# Altium schematic sheet ESC.SchDoc
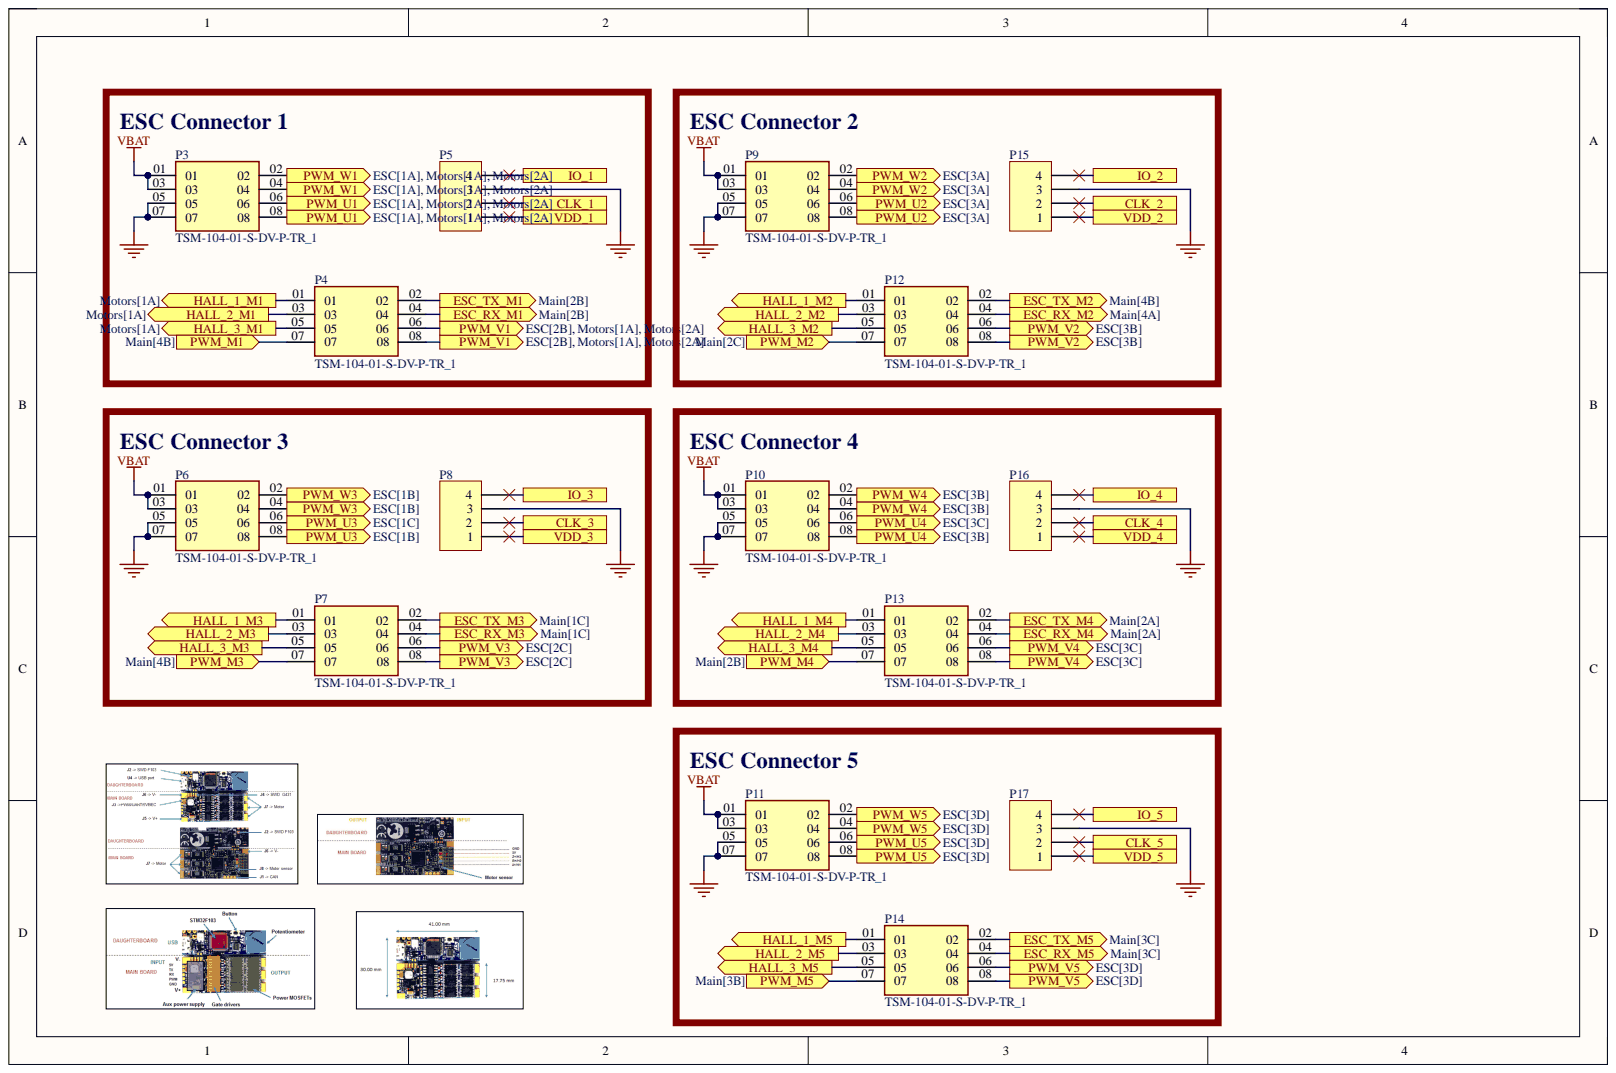
[diagram p0: ESC.SchDoc]

## Parts
P3  TSM-104-01-S-DV-P-TR_1  [TSM-104-01-S-DV-P-TR]
P4  TSM-104-01-S-DV-P-TR_1  [TSM-104-01-S-DV-P-TR]
P5  Header 3  [My_1X04]  (value not drawn)
P6  TSM-104-01-S-DV-P-TR_1  [TSM-104-01-S-DV-P-TR]
P7  TSM-104-01-S-DV-P-TR_1  [TSM-104-01-S-DV-P-TR]
P8  Header 3  [My_1X04]  (value not drawn)
P9  TSM-104-01-S-DV-P-TR_1  [TSM-104-01-S-DV-P-TR]
P10  TSM-104-01-S-DV-P-TR_1  [TSM-104-01-S-DV-P-TR]
P11  TSM-104-01-S-DV-P-TR_1  [TSM-104-01-S-DV-P-TR]
P12  TSM-104-01-S-DV-P-TR_1  [TSM-104-01-S-DV-P-TR]
P13  TSM-104-01-S-DV-P-TR_1  [TSM-104-01-S-DV-P-TR]
P14  TSM-104-01-S-DV-P-TR_1  [TSM-104-01-S-DV-P-TR]
P15  Header 3  [My_1X04]  (value not drawn)
P16  Header 3  [My_1X04]  (value not drawn)
P17  Header 3  [My_1X04]  (value not drawn)

## Ports
CLK_1, CLK_2, CLK_3, CLK_4, CLK_5, ESC_RX_M1, ESC_RX_M2, ESC_RX_M3, ESC_RX_M4, ESC_RX_M5, ESC_TX_M1, ESC_TX_M2, ESC_TX_M3, ESC_TX_M4, ESC_TX_M5, HALL_1_M1, HALL_1_M2, HALL_1_M3, HALL_1_M4, HALL_1_M5, HALL_2_M1, HALL_2_M2, HALL_2_M3, HALL_2_M4, HALL_2_M5, HALL_3_M1, HALL_3_M2, HALL_3_M3, HALL_3_M4, HALL_3_M5, IO_1, IO_2, IO_3, IO_4, IO_5, PWM_M1, PWM_M2, PWM_M3, PWM_M4, PWM_M5, PWM_U1, PWM_U2, PWM_U3, PWM_U4, PWM_U5, PWM_V1, PWM_V2, PWM_V3, PWM_V4, PWM_V5, PWM_W1, PWM_W2, PWM_W3, PWM_W4, PWM_W5, VDD_1, VDD_2, VDD_3, VDD_4, VDD_5

## Nets
GND: P3.05 P3.07 P5.3 P6.05 P6.07 P8.3 P9.05 P9.07 P10.05 P10.07 P11.05 P11.07 P15.3 P16.3 P17.3
VBAT: P3.01 P3.03 P6.01 P6.03 P9.01 P9.03 P10.01 P10.03 P11.01 P11.03
CLK_1: P5.2 ; port CLK_1
CLK_2: P15.2 ; port CLK_2
CLK_3: P8.2 ; port CLK_3
CLK_4: P16.2 ; port CLK_4
CLK_5: P17.2 ; port CLK_5
ESC_RX_M1: P4.04 ; port ESC_RX_M1
ESC_RX_M2: P12.04 ; port ESC_RX_M2
ESC_RX_M3: P7.04 ; port ESC_RX_M3
ESC_RX_M4: P13.04 ; port ESC_RX_M4
ESC_RX_M5: P14.04 ; port ESC_RX_M5
ESC_TX_M1: P4.02 ; port ESC_TX_M1
ESC_TX_M2: P12.02 ; port ESC_TX_M2
ESC_TX_M3: P7.02 ; port ESC_TX_M3
ESC_TX_M4: P13.02 ; port ESC_TX_M4
ESC_TX_M5: P14.02 ; port ESC_TX_M5
HALL_1_M1: P4.01 ; port HALL_1_M1
HALL_1_M2: P12.01 ; port HALL_1_M2
HALL_1_M3: P7.01 ; port HALL_1_M3
HALL_1_M4: P13.01 ; port HALL_1_M4
HALL_1_M5: P14.01 ; port HALL_1_M5
HALL_2_M1: P4.03 ; port HALL_2_M1
HALL_2_M2: P12.03 ; port HALL_2_M2
HALL_2_M3: P7.03 ; port HALL_2_M3
HALL_2_M4: P13.03 ; port HALL_2_M4
HALL_2_M5: P14.03 ; port HALL_2_M5
HALL_3_M1: P4.05 ; port HALL_3_M1
HALL_3_M2: P12.05 ; port HALL_3_M2
HALL_3_M3: P7.05 ; port HALL_3_M3
HALL_3_M4: P13.05 ; port HALL_3_M4
HALL_3_M5: P14.05 ; port HALL_3_M5
IO_1: P5.4 ; port IO_1
IO_2: P15.4 ; port IO_2
IO_3: P8.4 ; port IO_3
IO_4: P16.4 ; port IO_4
IO_5: P17.4 ; port IO_5
PWM_M1: P4.07 ; port PWM_M1
PWM_M2: P12.07 ; port PWM_M2
PWM_M3: P7.07 ; port PWM_M3
PWM_M4: P13.07 ; port PWM_M4
PWM_M5: P14.07 ; port PWM_M5
PWM_U1: P3.06 P3.08 ; port PWM_U1
PWM_U2: P9.06 P9.08 ; port PWM_U2
PWM_U3: P6.06 P6.08 ; port PWM_U3
PWM_U4: P10.06 P10.08 ; port PWM_U4
PWM_U5: P11.06 P11.08 ; port PWM_U5
PWM_V1: P4.06 P4.08 ; port PWM_V1
PWM_V2: P12.06 P12.08 ; port PWM_V2
PWM_V3: P7.06 P7.08 ; port PWM_V3
PWM_V4: P13.06 P13.08 ; port PWM_V4
PWM_V5: P14.06 P14.08 ; port PWM_V5
PWM_W1: P3.02 P3.04 ; port PWM_W1
PWM_W2: P9.02 P9.04 ; port PWM_W2
PWM_W3: P6.02 P6.04 ; port PWM_W3
PWM_W4: P10.02 P10.04 ; port PWM_W4
PWM_W5: P11.02 P11.04 ; port PWM_W5
VDD_1: P5.1 ; port VDD_1
VDD_2: P15.1 ; port VDD_2
VDD_3: P8.1 ; port VDD_3
VDD_4: P16.1 ; port VDD_4
VDD_5: P17.1 ; port VDD_5

## Library data of the parts
P3 P4 P6 P7 P9-P14 = TSM-104-01-S-DV-P-TR_1: MF: Samtec; Availability: Unavailable; Component Kind: Standard; MP: TSM-104-01-S-DV-P-TR; Package: None; Price: None; Library Name: roboime.SchLib
P5 P8 P15-P17 = Header 4_1: Header, 4-Pin; ASiD Part Number: Header 4-AS; Component Kind: Standard; Signal Integrity: Connector; Library Name: roboime.SchLib
